# Altium schematic sheet GSMLiftSquare.SchDoc(GSMLiftSquare)
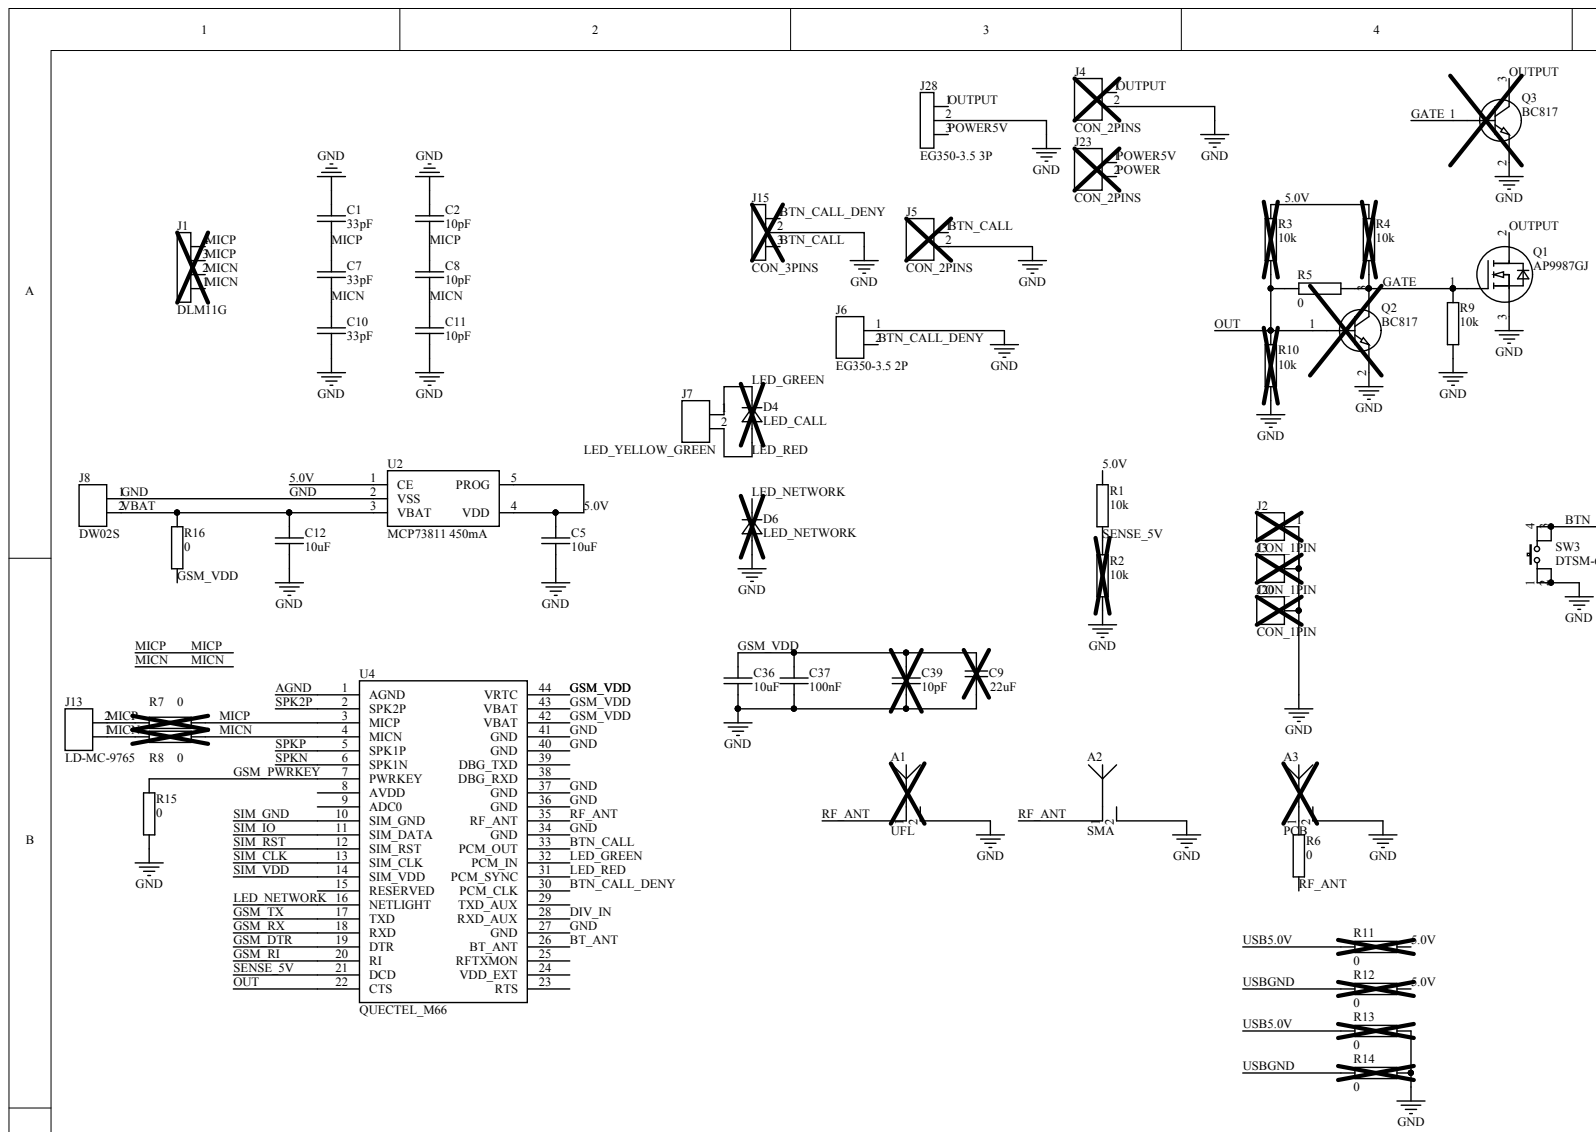
[diagram p0: GSMLiftSquare.SchDoc, top left]
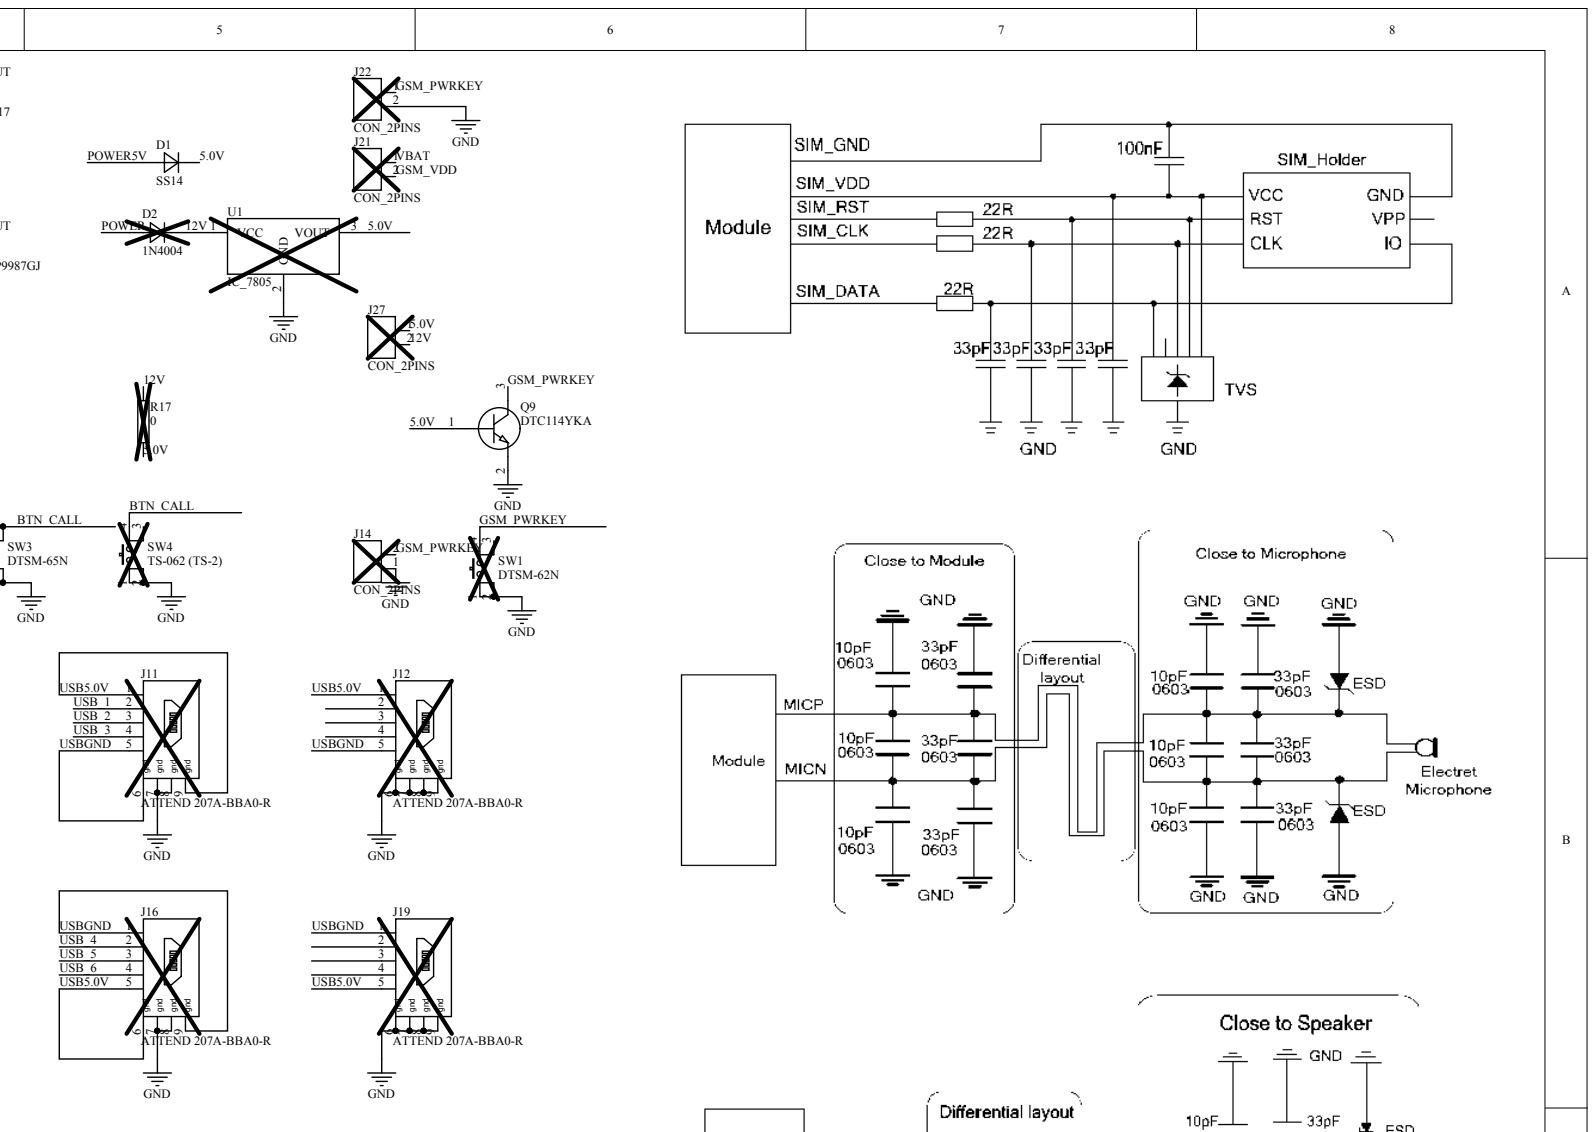
[diagram p1: GSMLiftSquare.SchDoc, top right]
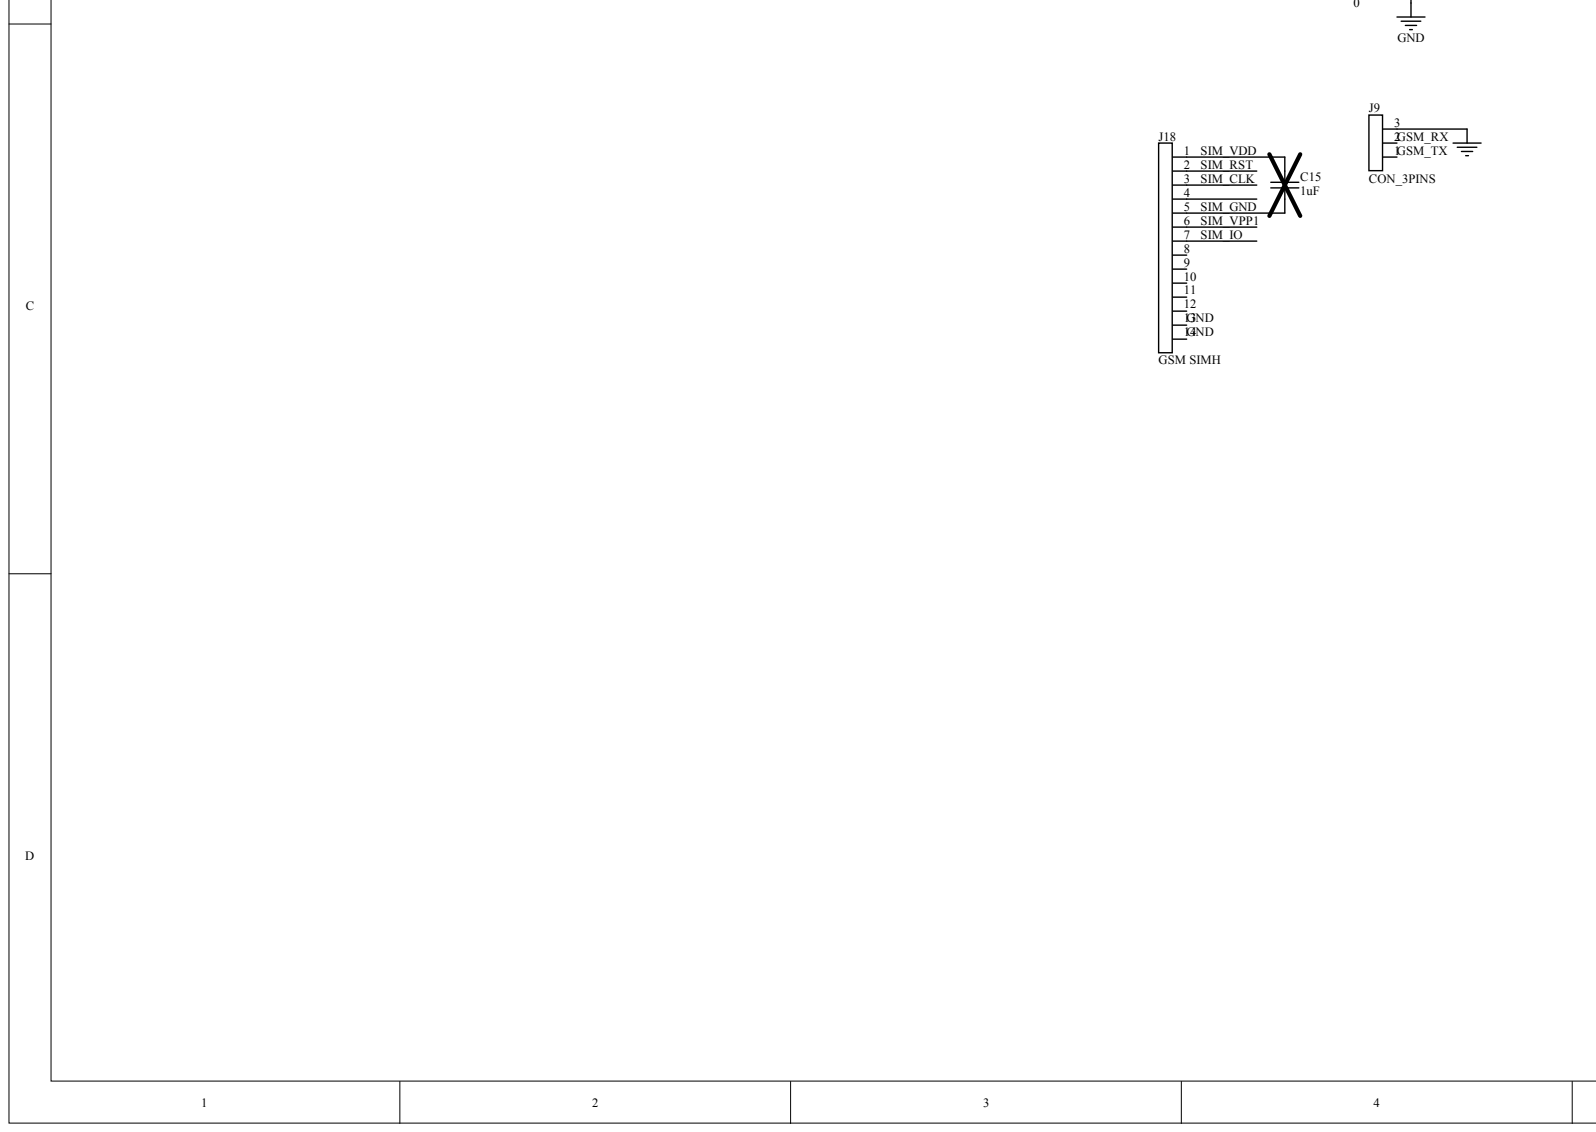
[diagram p2: GSMLiftSquare.SchDoc, bottom left]
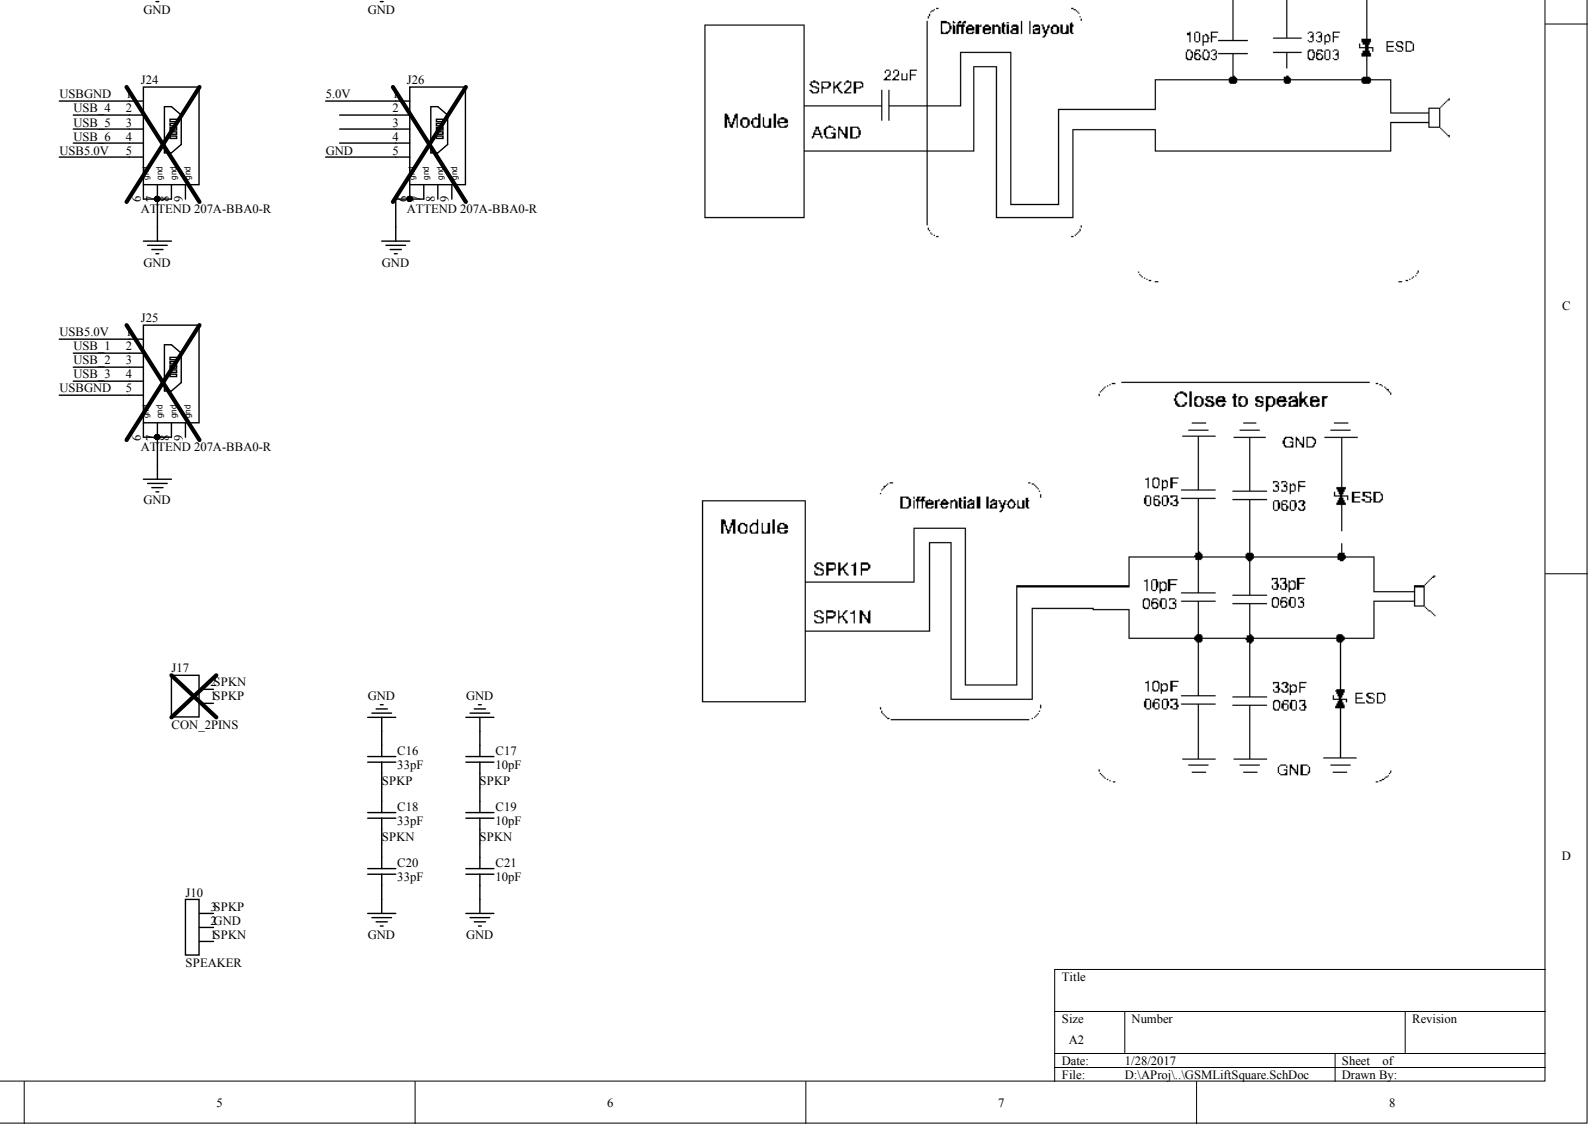
[diagram p3: GSMLiftSquare.SchDoc, bottom right]

## Parts
A1  UFL  [SMD_CON_ANTENNA_UFL]
A2  SMA  [SMD_CON_ANTENNA_SMA_RIGHT_ANGLE]
A3  PCB  [SMD_CON_ANTENNA_GSM_PCB_1]
C1  33pF  [SMD_0603_CAPC1608X95N]
C2  10pF  [SMD_0603_CAPC1608X95N]
C5  10uF  [SMD_0603_CAPC1608X95N]
C7  33pF  [SMD_0603_CAPC1608X95N]
C8  10pF  [SMD_0603_CAPC1608X95N]
C9  22uF  [SMD_1210_CAPC3225X279N]
C10  33pF  [SMD_0603_CAPC1608X95N]
C11  10pF  [SMD_0603_CAPC1608X95N]
C12  10uF  [SMD_0603_CAPC1608X95N]
C15  1uF  [SMD_0603_CAPC1608X95N]
C16  33pF  [SMD_0603_CAPC1608X95N]
C17  10pF  [SMD_0603_CAPC1608X95N]
C18  33pF  [SMD_0603_CAPC1608X95N]
C19  10pF  [SMD_0603_CAPC1608X95N]
C20  33pF  [SMD_0603_CAPC1608X95N]
C21  10pF  [SMD_0603_CAPC1608X95N]
C36  10uF  [SMD_0805_CAPC2012X95N]
C37  100nF  [SMD_0603_CAPC1608X95N]
C39  10pF  [SMD_0603_CAPC1608X95N]
D1  SS14  [SMD_DO214AC_SMA]
D2  1N4004  [SMD_DO214AC_SMA]
D4  LED_CALL  [SMD_0805_LED2012X100N]
D6  LED_NETWORK  [SMD_0805_LED2012X100N]
J1  DLM11G  [SMD_COMMON_MODE_CHOKE]
J2  CON_1PIN  [PTH_MOUNT_HOLE]
J3  CON_1PIN  [PTH_MOUNT_HOLE]
J4  CON_2PINS  [PTH_HDRV02W11060P350_1X02]
J5  CON_2PINS  [PTH_HDRV02W11060P500_1X02]
J6  EG350-3.5 2P  [PTH_HDRV02W11060P350_1X02]
J7  LED_YELLOW_GREEN  [PTH_LED-KINGBRIGHT-L-934SGC]
J8  DW02S  [PTH_HDRV02W64P254_1X02_BATTERY]
J9  CON_3PINS  [PTH_HDRV03W64P254_1X03]
J10  SPEAKER  [PTH_SPEAKER_MCABS-227-RC]
J11  ATTEND 207A-BBA0-R  [SMD_CON_MICRO_USB]
J12  ATTEND 207A-BBA0-R  [SMD_CON-CVILUX-CU04-SCM15B0]
J13  LD-MC-9765  [PTH_MIC_9.6MM_6MM]
J14  CON_2PINS  [PTH_HDRV02W64P254_1X02]
J15  CON_3PINS  [PTH_HDRV03W64P254_1X03]
J16  ATTEND 207A-BBA0-R  [SMD_CON_MICRO_USB]
J17  CON_2PINS  [PTH_HDRV02W64P254_1X02]
J18  GSM SIMH  [SMD_CON-ATTEND_SIM_115B-AAA0-R01]
J19  ATTEND 207A-BBA0-R  [SMD_CON-CVILUX-CU04-SCM15B0]
J20  CON_1PIN  [PTH_MOUNT_HOLE]
J21  CON_2PINS  [PTH_HDRV02W64P254_1X02]
J22  CON_2PINS  [PTH_HDRV02W64P254_1X02]
J23  CON_2PINS  [PTH_HDRV02W11060P350_1X02]
J24  ATTEND 207A-BBA0-R  [SMD_CON_MICRO_USB_STRAIGHT]
J25  ATTEND 207A-BBA0-R  [SMD_CON_MICRO_USB_STRAIGHT]
J26  ATTEND 207A-BBA0-R  [PTH_CON_MINI_USB_STRAIGHT]
J27  CON_2PINS  [PTH_HDRV02W64P254_1X02]
J28  EG350-3.5 3P  [PTH_HDRV02W11060P350_1X03]
Q1  AP9987GJ  [PTH_TO220AB]
Q2  BC817  [SMD_SOT23_SOT95P240X120-3N]
Q3  BC817  [SMD_SOT23_SOT95P240X120-3N]
Q9  DTC114YKA  [SMD_SOT23_SOT95P240X120-3N]
R1  10k  [SMD_0603_RESC1608X55N]
R2  10k  [SMD_0603_RESC1608X55N]
R3  10k  [SMD_0603_RESC1608X55N]
R4  10k  [SMD_0603_RESC1608X55N]
R5  0  [SMD_0603_RESC1608X55N]
R6  0  [SMD_0402_RESC1005X37N]
R7  0  [SMD_0402_RESC1005X37N]
R8  0  [SMD_0402_RESC1005X37N]
R9  10k  [SMD_0603_RESC1608X55N]
R10  10k  [SMD_0603_RESC1608X55N]
R11  0  [SMD_0603_RESC1608X55N]
R12  0  [SMD_0603_RESC1608X55N]
R13  0  [SMD_0603_RESC1608X55N]
R14  0  [SMD_0603_RESC1608X55N]
R15  0  [SMD_0603_RESC1608X55N]
R16  0  [SMD_0603_RESC1608X55N]
R17  0  [SMD_0603_RESC1608X55N]
SW1  DTSM-62N  [SMD_SW_TACT-64K_5]
SW3  DTSM-65N  [SMD_SW_TACT-64K_5]
SW4  TS-062 (TS-2)  [PTH_SWI_TACT-62K_5]
U1  IC_7805  [PTH_TO220AB]
U2  MCP73811 450mA  [SMD_SOT26_SOT95P285X140-5N - DLV]
U4  QUECTEL_M66  [SMD_MOD_M66-44N]

## Nets
5.0V: C5.1 D1.1 J26.1 J27.1 Q9.1 R1.1 R3.1 R4.1 R11.1 R12.1 R17.2 U1.3 U2.1(CE) U2.4(VDD) U2.5(PROG)
12V: D2.1 J27.2 R17.1 U1.1
AGND: U4.1(AGND)
BT_ANT: U4.26(BT_ANT)
BTN_CALL: J5.1 J15.3 SW3.3 SW3.4 SW4.4 U4.33(PCM_OUT)
BTN_CALL_DENY: J6.2 J15.1 U4.30(PCM_CLK)
DIV_IN: U4.28(RXD_AUX)
GATE: Q1.1 Q2.3 Q3.1 R4.2 R5.2 R9.1
GND: A1.2 A2.2 A3.2 C1.1 C2.1 C5.2 C9.2 C10.2 C11.2 C12.2 C16.1 C17.1 C20.2 C21.2 C36.2 C37.2 C39.2 D6.2 J2.1 J3.1 J4.2 J5.2 J6.1 J8.1 J9.3 J10.2 J11.7 J11.8 J12.6(gnd) J12.7 J12.8 J12.9(gnd) J14.1 J15.2 J16.7 J16.8 J18.13 J18.14 J19.6(gnd) J19.7 J19.8 J19.9(gnd) J20.1 J22.2 J24.6 J24.7 J24.8 J25.6 J25.7 J25.8 J26.5 J26.6 J26.7 J28.2 Q1.3 Q2.2 Q3.2 Q9.2 R2.2 R9.2 R10.2 R13.1 R14.1 R15.2 SW1.1 SW1.2 SW3.1 SW3.2 SW4.1 SW4.2 U1.2 U2.2(VSS) U4.27(GND) U4.34(GND) U4.36(GND) U4.37(GND) U4.40(GND) U4.41(GND)
GSM_DTR: U4.19(DTR)
GSM_PWRKEY: J14.2 J22.1 Q9.3 R15.1 SW1.4 U4.7(PWRKEY)
GSM_RI: U4.20(RI)
GSM_RX: J9.2 U4.18(RXD)
GSM_TX: J9.1 U4.17(TXD)
GSM_VDD: C9.1 C36.1 C37.1 C39.1 J21.2 R16.1 U4.42(VBAT) U4.43(VBAT) U4.44(VRTC)
LED_GREEN: D4.1 J7.1 U4.32(PCM_IN)
LED_NETWORK: D6.1 U4.16(NETLIGHT)
LED_RED: D4.2 J7.2 U4.31(PCM_SYNC)
MICN: C7.2 C8.2 C10.1 C11.1 J1.1 J1.2 J13.1 R8.1 R8.2 U4.4(MICN)
MICP: C1.2 C2.2 C7.1 C8.1 J1.3 J1.4 J13.2 R7.1 R7.2 U4.3(MICP)
OUT: Q2.1 R3.2 R5.1 R10.1 U4.22(CTS)
OUTPUT: J4.1 J28.1 Q1.2 Q3.3
POWER: D2.2 J23.2
POWER5V: D1.2 J23.1 J28.3
RF_ANT: A1.1 A2.1 R6.2 U4.35(RF_ANT)
SENSE_5V: R1.2 R2.1 U4.21(DCD)
SIM_CLK: J18.3 U4.13(SIM_CLK)
SIM_GND: C15.2 J18.5 U4.10(SIM_GND)
SIM_IO: J18.7 U4.11(SIM_DATA)
SIM_RST: J18.2 U4.12(SIM_RST)
SIM_VDD: C15.1 J18.1 U4.14(SIM_VDD)
SIM_VPP1: J18.6
SPK2P: U4.2(SPK2P)
SPKN: C18.2 C19.2 C20.1 C21.1 J10.1 J17.2 U4.6(SPK1N)
SPKP: C16.2 C17.2 C18.1 C19.1 J10.3 J17.1 U4.5(SPK1P)
USB5.0V: J11.1 J11.9 J12.1 J16.5 J16.6 J19.5 J24.5 J25.1 R11.2 R13.2
USB_1: J11.2 J25.2
USB_2: J11.3 J25.3
USB_3: J11.4 J25.4
USB_4: J16.2 J24.2
USB_5: J16.3 J24.3
USB_6: J16.4 J24.4
USBGND: J11.5 J11.6 J12.5 J16.1 J16.9 J19.1 J24.1 J25.5 R12.2 R14.2
VBAT: C12.1 J8.2 J21.1 R16.2 U2.3(VBAT)
NetA3_1: A3.1 R6.1

Not connected: J12 2 3 4; J18 4 8 9 10 11 12; J19 2 3 4; J24 9; J25 9; J26 2 3 4 8(gnd) 9; SW1 3; SW4 3; U4 8(AVDD) 9(ADC0) 15(RESERVED) 23(RTS) 24(VDD_EXT) 25(RFTXMON) 29(TXD_AUX) 38(DBG_RXD) 39(DBG_TXD)

## Library data of the parts
A1-A3 = ANTENNA_2: NOTE: <NOTE>; Component Kind: Standard; Library Name: DLV.SchLib
C1 C2 C5 C7 C8 C10-C12 C15-C21 C36 C37 C39 = C: NOTE: <NOTE>; Component Kind: Standard; Library Name: DLV.SchLib
C9 = Cap Semi: CL32A227MQVNNNE 220UF 6.3V 20% X5R 1210; Component Kind: Standard; LatestRevisionDate: 29-May-2009; LatestRevisionNote: IPC-7351 Footprint Added.; Library Name: Miscellaneous Devices.IntLib; PackageDescription: Chip Capacitor; PackageReference: C1206; Published: 8-Jun-2000; Signal Integrity: Cap Semi; Simulation: CAP; Value: 22uF; Publisher: Altium Limited
D1 D2 D4 D6 = D: NOTE: <NOTE>; Component Kind: Standard
  D1 D2: Library Name: EPIQ_DND_CIS_Library.SchLib
  D4 D6: Library Name: DLV.SchLib
J1 = CON_4PINS: Component Kind: Standard; Library Name: DLV.SchLib
J2 J3 J20 = CON_1PIN: NOTE: <NOTE>; Component Kind: Standard; Library Name: DLV.SchLib
J4-J8 J13 J14 J17 J21-J23 J27 = CON_2PINS: NOTE: <NOTE>; Component Kind: Standard; Library Name: DLV.SchLib
J10 J28 = CON_3PINS: Component Kind: Standard; Library Name: DLV.SchLib
J11 J12 J16 J19 J24-J26 = CON_USB_MINI: NOTE: <NOTE>; Component Kind: Standard; Library Name: DLV.SchLib
J18 = CON_14PINS: Component Kind: Standard; Library Name: DLV.SchLib
Q1 = MOS_N_D1_G1D2S3: NOTE: <NOTE>; Component Kind: Standard; Library Name: DLV.SchLib
Q2 Q3 Q9 = BJT_NPN_B1E2C3: NOTE: <NOTE>; Component Kind: Standard; Library Name: DLV.SchLib
R1-R17 = R: NOTE: <NOTE>; Component Kind: Standard; R_BDS: R; Library Name: DLV.SchLib
SW1 SW3 SW4 = BUTTON_1: Component Kind: Standard; Library Name: DLV.SchLib
U1 = IC_7805: NOTE: <NOTE>; Component Kind: Standard; Library Name: DLV.SchLib
U2 = (no symbol name): MCP73812; DB_MANUFACTURER_PART: ROHM        BD33KA5WF-E2; DB_PART_NUMBER: PSU000000127; DB_PART_TYPE: Linear Voltage Regulator; DB_APPROVED_BY: VSN; DB_APPROVED_ON: 07.5.2014 г. 13:18:28; DB_CERTIFIED: ISHIDDEN=T; DB_CURRENT: 500mA; DB_DESCRIPTION: IC BD33KA5WF-E2 SOIC-8; DB_PACKAGE: SOIC-8; DB_PCB_DEVICE: SMD_SOIC127P620X160-8N; DB_STATUS: NEW; DB_T_RANGE: -40 to 105; DB_VOLTAGE: 3.3V; Component Kind: Standard; DB_DATASHEET: http://john.epiqdesign.com/projects/CIS_Datasheets/IC_bdxxka5wf-e-209388.pdf?rev=1408&sc=0; Library Name: DLV.SchLib
U4 = MOD_QUECTEL_M66: Component Kind: Standard; Library Name: DLV.SchLib
J9 J15 = CON_3PINS: Component Kind: Standard; Library Name: DLV.SchLib
